# Altium schematic sheet 2414_TouchCapa.SchDoc
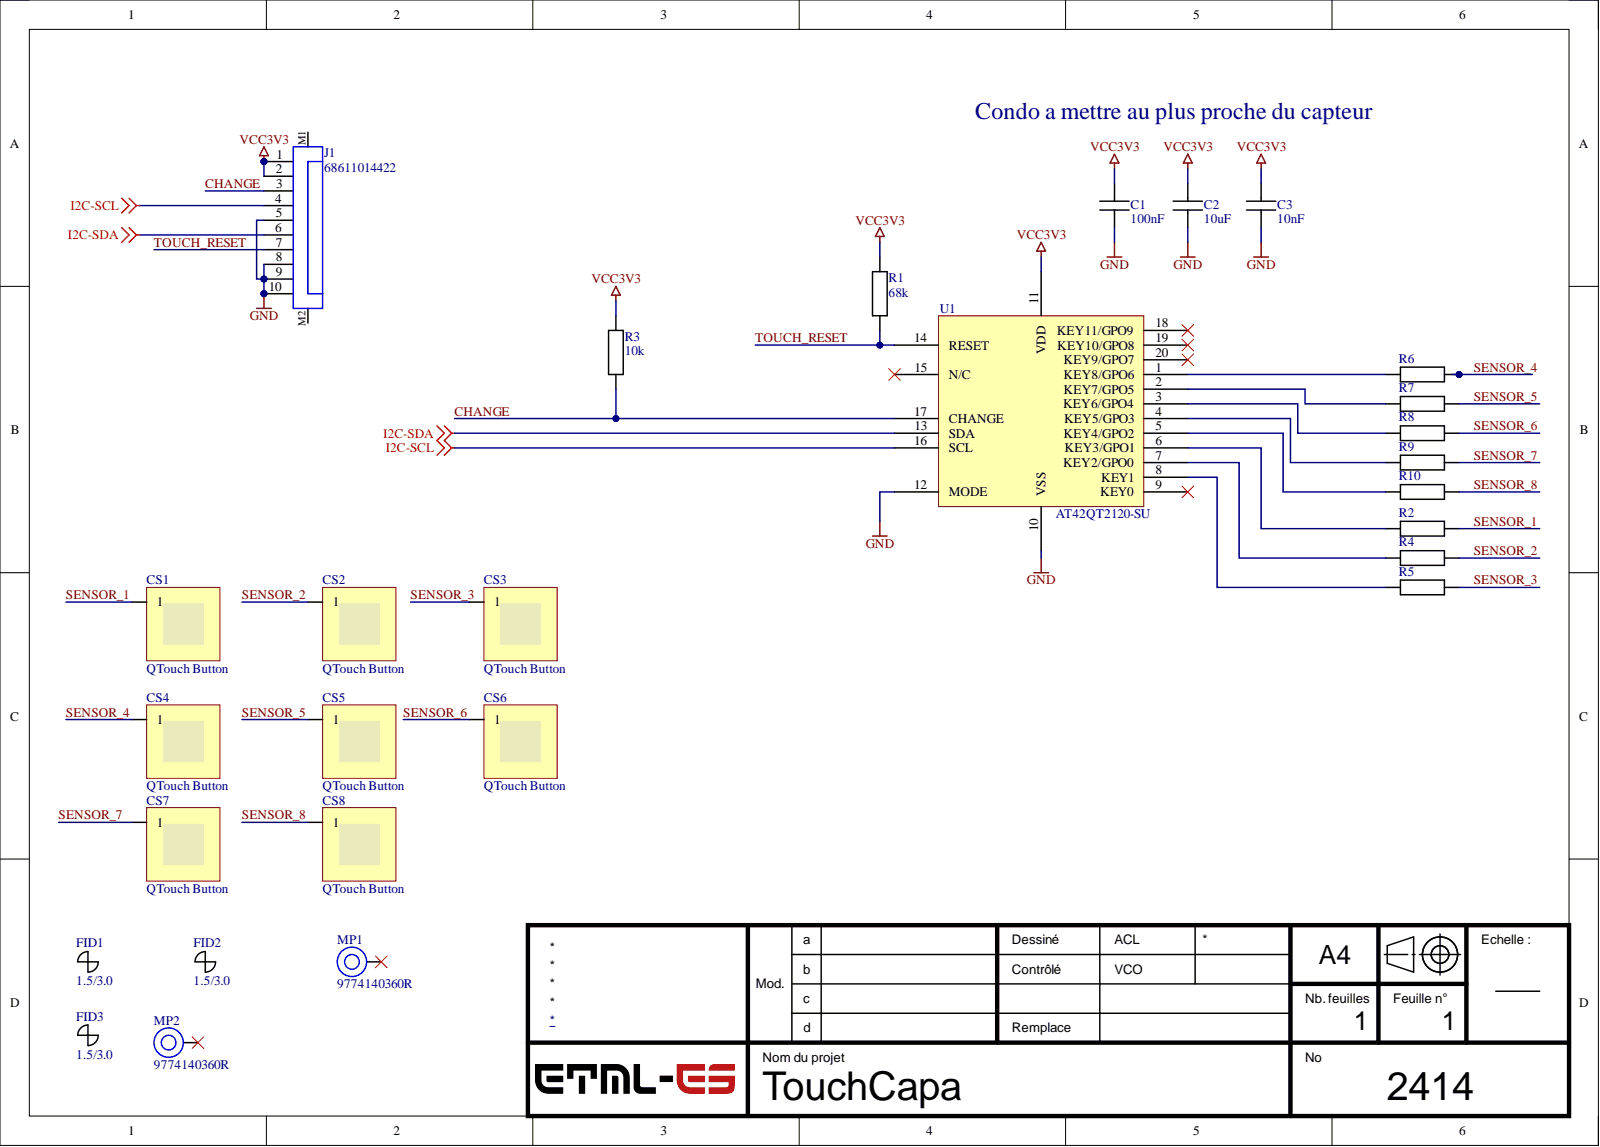
[diagram p0: 2414_TouchCapa.SchDoc]

## Parts
C1  100nF  [CAPC1608X08M]
C2  10uF  [CAPC1608X08M]
C3  10nF  [CAPC1608X08M]
CS1  QTouch Button  (no BOM)
CS2  QTouch Button  (no BOM)
CS3  QTouch Button  (no BOM)
CS4  QTouch Button  (no BOM)
CS5  QTouch Button  (no BOM)
CS6  QTouch Button  (no BOM)
CS7  QTouch Button  (no BOM)
CS8  QTouch Button  (no BOM)
FID1  1.5/3.0  [FID-150-300]  (no BOM)
FID2  1.5/3.0  [FID-150-300]  (no BOM)
FID3  1.5/3.0  [FID-150-300]  (no BOM)
J1  68611014422  [68611014422]
MP1  9774140360R  [9774140360]
MP2  9774140360R  [9774140360]
R1  68k  [RESC1608X06M]
R2  4k7  [RESC1608X06M]  (value not drawn)
R3  10k  [RESC1608X06M]
R4  4k7  [RESC1608X06M]  (value not drawn)
R5  4k7  [RESC1608X06M]  (value not drawn)
R6  4k7  [RESC1608X06M]  (value not drawn)
R7  4k7  [RESC1608X06M]  (value not drawn)
R8  4k7  [RESC1608X06M]  (value not drawn)
R9  4k7  [RESC1608X06M]  (value not drawn)
R10  4k7  [RESC1608X06M]  (value not drawn)
U1  AT42QT2120-SU  [SOIC20-W_MC_MCH-L]

## Nets
GND: C1.1 C2.1 C3.1 J1.5 J1.8 J1.9 J1.10 U1.10(VSS) U1.12(MODE)
I2C-SCL: J1.4 U1.16(SCL)
I2C-SDA: J1.6 U1.13(SDA)
VCC3V3: C1.2 C2.2 C3.2 J1.1 J1.2 R1.2 R3.2 U1.11(VDD)
CHANGE: J1.3 R3.1 U1.17(CHANGE)
SENSOR_1: CS1.1 R2.2
SENSOR_2: CS2.1 R4.2
SENSOR_3: CS3.1 R5.2
SENSOR_4: CS4.1 R6.2
SENSOR_5: CS5.1 R7.2
SENSOR_6: CS6.1 R8.2
SENSOR_7: CS7.1 R9.2
SENSOR_8: CS8.1 R10.2
TOUCH_RESET: J1.7 R1.1 U1.14(RESET)
NetR2_1: R2.1 U1.6(KEY3/GPO1)
NetR4_1: R4.1 U1.7(KEY2/GPO0)
NetR5_1: R5.1 U1.8(KEY1)
NetR6_1: R6.1 U1.1(KEY8/GPO6)
NetR7_1: R7.1 U1.2(KEY7/GPO5)
NetR8_1: R8.1 U1.3(KEY6/GPO4)
NetR9_1: R9.1 U1.4(KEY5/GPO3)
NetR10_1: R10.1 U1.5(KEY4/GPO2)

Not connected: J1 M1 M2; MP1 1; MP2 1; U1 9(KEY0) 15(N/C) 18(KEY11/GPO9) 19(KEY10/GPO8) 20(KEY9/GPO7)

## Library data of the parts
C1 = CMP-065-000000-2: CAPC 100nF X7R 50V 10% 1608 (0603 INCH); Manufacturer: TDK; Manufacturer Number: CGA3E2X7R1H104K080AA; Current: NULL; IsStocked: 1; PN: PN-338083; TemperatureRange: -55°C to +125°C; Category: Passives; Component Kind: Standard; Dielectric: X7R; Library Name: ETML-ES; Power: NULL; SubCategory: Condensateurs ceramique; Supplier 1: ETML; Supplier Part Number 1: FAB.0603_C 100n; Supplier Price 1: 0.0100; TemperatureCoeff: NULL; Tolerance: ±10%; Value: 100nF; Voltage: 50V
C2 = CMP-065-000003-2: CAPC 10uF X5R 10V 20% 1608 (0603 INCH); Manufacturer: TDK; Manufacturer Number: C1608X5R1A106M080AC; IsStocked: 1; PN: PN-131657; TemperatureRange: -55°C to +85°C; Value: 10µF; Category: Passives; Component Kind: Standard; Dielectric: X5R; Library Name: ETML-ES; SubCategory: Condensateurs ceramique; Supplier 1: ETML; Supplier Part Number 1: FAB.0603_C 10uF; Supplier Price 1: 0.0944; Tolerance: ±20%; Voltage: 10V
C3 = CMP-065-000010-2: CAPC 10nF X7R 50V 10% 1608 (0603 INCH); Manufacturer: TDK; Manufacturer Number: C1608X7R1H103K080AA; IsStocked: 1; PN: PN-514948; TemperatureRange: -55°C to +125°C; Category: Passives; Component Kind: Standard; Dielectric: X7R; Library Name: ETML-ES; SubCategory: Condensateurs ceramique; Supplier 1: ETML; Supplier Part Number 1: FAB.0603_C 10nF; Supplier Price 1: 0.0123; Tolerance: ±10%; Value: 10nF; Voltage: 50V
CS1-CS8 = QTouchButton: ConfigurationParameters: {}__sensorid[1]{}width[15]{}height[15]{}; ConfiguratorName: ATMEL_TOUCH; Description: QTouch Button; Library Name: Atmel QTouch.IntLib
FID1-FID3 = CMP-063-000021-1: FID 1.5/3.0; IsStocked: False; PN: PN-509779; Category: Others; Library Name: ETML-ES; SubCategory: Fiducials; Value: 1.5/3.0
J1 = CMP-1502-01457-2: NexarPartId: 8917674; Actuator Material: Polyphenylene; Contact Resistance: 20mΩ; Current Rating: 1A; Gender: Male; Insulation Resistance: 100MΩ; Number of Contacts: 10; Operating Supply Voltage: 125V; Pitch: 1mm; Component Kind: Standard; Contact Material: Bronze, Tin; Contact Plating: Tin; Flammability Rating: UL94 V-0; Housing Material: Polyphenylene; Lead Pitch: 1mm; Length: 17mm; Library Name: Altium Content Vault; Max Operating Temperature: 85°C; Min Operating Temperature: -25°C; Mount: Surface Mount; Number of Pins: 10; Number of Positions: 10; Number of Rows: 1; Orientation: Horizontal; Packaging: Tape and Reel; REACH SVHC: Unknown; RoHS: Compliant; Termination: Solder; Voltage Rating: 125V; Width: 3mm
MP1 MP2 = CMP-03913-39399861-1: 9774140360R Spacer Material: Steel, Tin-Plated Mounting: SMT Series: WA-SMSI Thread D: M3; Outside Diameter: 5.9944mm; Plating: Tin; Threaded/Unthreaded: Threaded; Component Kind: Standard; Length: 14mm; Library Name: Altium Content Vault; Material: Steel; Max Operating Temperature: 150°C; Min Operating Temperature: -55°C; Mount: External; NexarPartId: 39399861; Packaging: Tape and Reel; REACH SVHC: Unknown; RoHS: Compliant; Thread Size: M3; Width: 6mm
R1 = CMP-066-000134-2: RES 68kohm  ±1% 0.1W  1608 (0603 INCH); Manufacturer: Yageo; Manufacturer Number: RC0603FR-0768KL; IsStocked: 1; PN: PN-287203; TemperatureCoeff: ±100ppm/°C; TemperatureRange: -55°C to 155°C; Voltage: 75V; Category: Passives; Component Kind: Standard; Library Name: ETML-ES; Power: 0.1W; SubCategory: Resistances couche epaisse; Supplier 1: ETML; Supplier Part Number 1: FAB.0603_R 68k; Supplier Price 1: 0.0200; Tolerance: ±1%; Value: 68k
R2 R4-R10 = CMP-066-000120-2: RES 4.7kohm  ±1% 0.1W  1608 (0603 INCH); Manufacturer: Yageo; Manufacturer Number: RC0603FR-074K7L; IsStocked: 1; PN: PN-420620; TemperatureCoeff: ±100ppm/°C; TemperatureRange: -55°C to 155°C; Value: 4.7k; Voltage: 75V; Category: Passives; Component Kind: Standard; Library Name: ETML-ES; Power: 0.1W; SubCategory: Resistances couche epaisse; Supplier 1: ETML; Supplier Part Number 1: FAB.0603_R 4k7; Supplier Price 1: 0.0200; Tolerance: ±1%
R3 = CMP-066-000124-2: RES 10kohm  ±1% 0.1W  1608 (0603 INCH); Manufacturer: Yageo; Manufacturer Number: RC0603FR-0710KL; IsStocked: 1; PN: PN-896786; TemperatureCoeff: ±100ppm/°C; TemperatureRange: -55°C to 155°C; Voltage: 75V; Category: Passives; Component Kind: Standard; Library Name: ETML-ES; Power: 0.1W; SubCategory: Resistances couche epaisse; Supplier 1: ETML; Supplier Part Number 1: FAB.0603_R 10k; Supplier Price 1: 0.0200; Tolerance: ±1%; Value: 10k
U1 = Component_1: Component Kind: Standard; Library Name: AT42QT2120.SchLib
